# Altium schematic sheet STM32F10RBT6通用开发板_普通接口.SchDoc
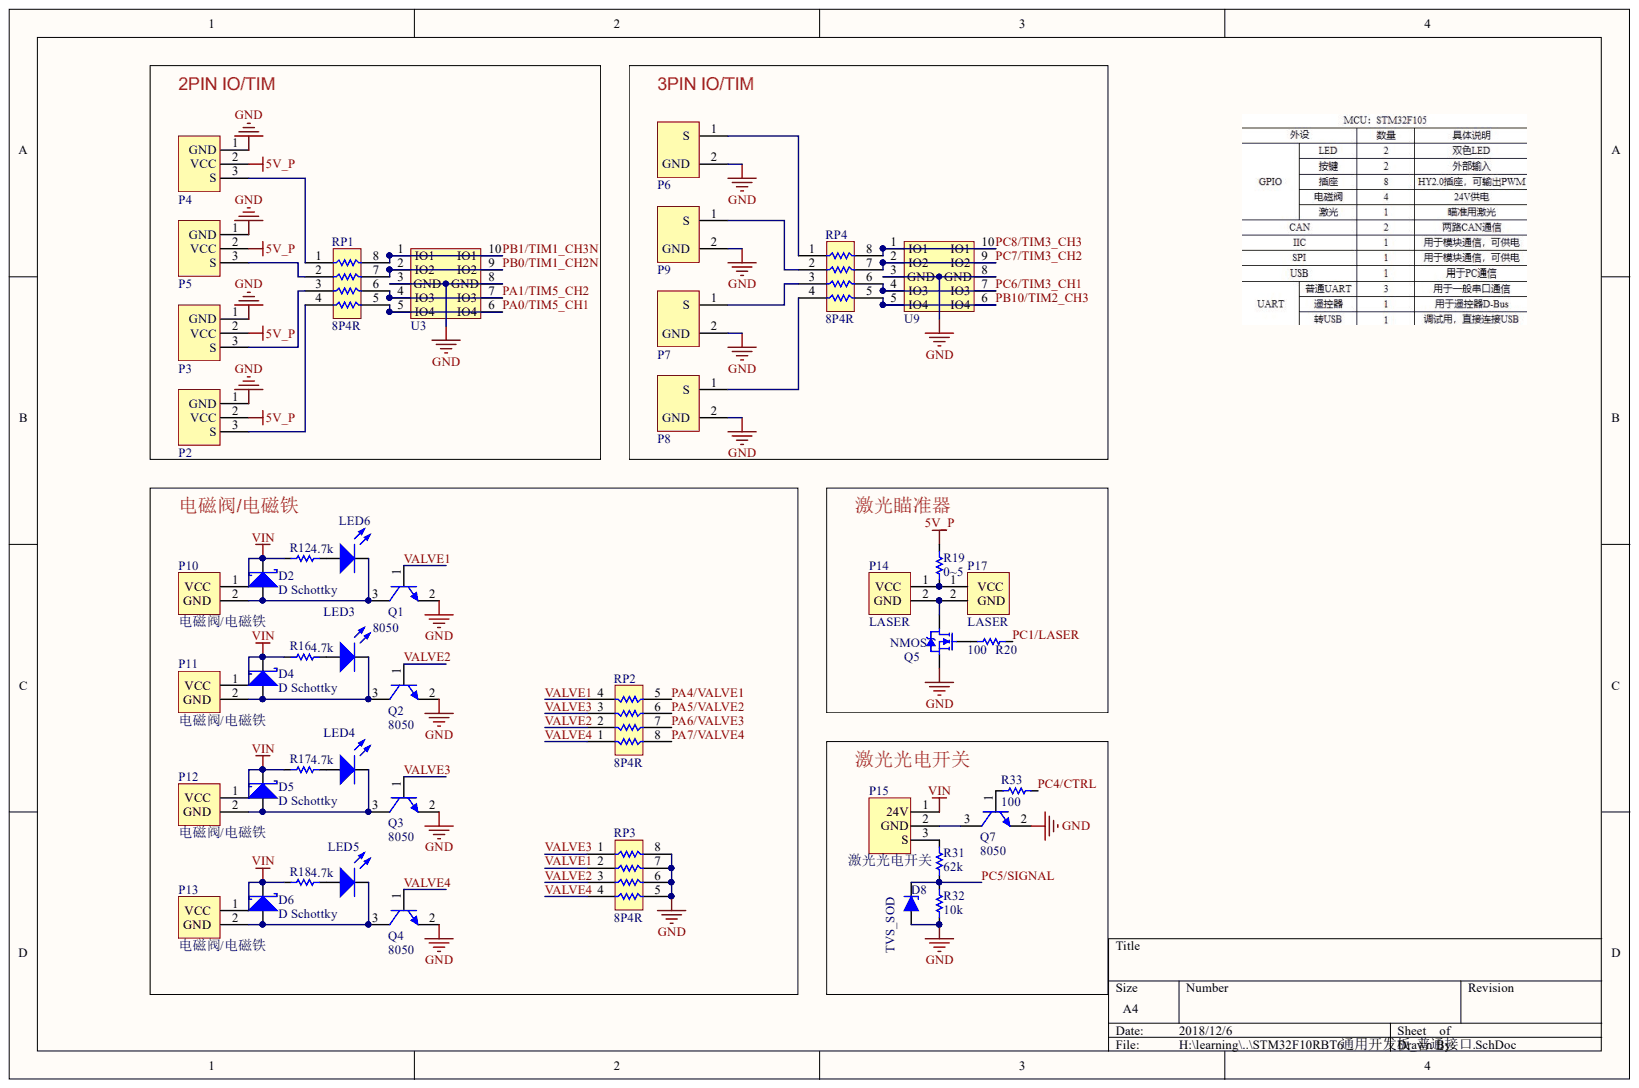
[diagram p0: STM32F10RBT6通用开发板_普通接口.SchDoc]

## Parts
D2  D Schottky  [SOD-323-]
D4  D Schottky  [SOD-323-]
D5  D Schottky  [SOD-323-]
D6  D Schottky  [SOD-323-]
D8  TVS_SOD  [SOD-323-]
LED3  LED_RED  [0603LED_B]  (value not drawn)
LED4  LED_RED  [0603LED_B]  (value not drawn)
LED5  LED_RED  [0603LED_B]  (value not drawn)
LED6  LED_RED  [0603LED_B]  (value not drawn)
P2  3Pin_IO  [HY2.00MM 3x1立式]  (value not drawn)
P3  3Pin_IO  [HY2.00MM 3x1立式]  (value not drawn)
P4  3Pin_IO  [HY2.00MM 3x1立式]  (value not drawn)
P5  3Pin_IO  [HY2.00MM 3x1立式]  (value not drawn)
P6  2pin_IO  [HY2.00MM 2X1_立式]  (value not drawn)
P7  2pin_IO  [HY2.00MM 2X1_立式]  (value not drawn)
P8  2pin_IO  [HY2.00MM 2X1_立式]  (value not drawn)
P9  2pin_IO  [HY2.00MM 2X1_立式]  (value not drawn)
P10  电磁阀/电磁铁  [HY2.00MM 2X1_立式]
P11  电磁阀/电磁铁  [HY2.00MM 2X1_立式]
P12  电磁阀/电磁铁  [HY2.00MM 2X1_立式]
P13  电磁阀/电磁铁  [HY2.00MM 2X1_立式]
P14  LASER  [HY2.00MM 2X1_立式]
P15  激光光电开关  [HY2.00MM 3x1立式]
P17  LASER  [1.25mm 2p 立式]
Q1  8050  [SOT-23-3]
Q2  8050  [SOT-23-3]
Q3  8050  [SOT-23-3]
Q4  8050  [SOT-23-3]
Q5  NMOS  [SOT-23-3]
Q7  8050  [SOT-23-3]
R12  4.7k  [0603R]  (value not drawn)
R16  4.7k  [0603R]  (value not drawn)
R17  4.7k  [0603R]  (value not drawn)
R18  4.7k  [0603R]  (value not drawn)
R19  0~5  [0603R]
R20  100  [0603R]
R31  62k  [0603R]
R32  10k  [0603R]
R33  100  [0603R]
RP1  8P4R  [RES-8P4R-0603]
RP2  8P4R  [RES-8P4R-0603]
RP3  8P4R  [RES-8P4R-0603]
RP4  8P4R  [RES-8P4R-0603]
U3  ESD-4channels_type2  [DQA0010A]  (value not drawn)
U9  ESD-4channels_type2  [DQA0010A]  (value not drawn)

## Nets
5V_P: P2.2 P3.2 P4.2 P5.2 R19.2
GND: D8.1 P2.1 P3.1 P4.1 P5.1 P6.2 P7.2 P8.2 P9.2 Q1.2 Q2.2 Q3.2 Q4.2 Q5.2 Q7.2 R32.1 RP3.5 RP3.6 RP3.7 RP3.8 U3.3(GND) U3.8(GND) U9.3(GND) U9.8(GND)
VIN: D2.2 D4.2 D5.2 D6.2 P10.1 P11.1 P12.1 P13.1 P15.1 R12.1 R16.1 R17.1 R18.1
PA0/TIM5_CH1: RP1.5 U3.5(IO4) U3.6(IO4)
PA1/TIM5_CH2: RP1.6 U3.4(IO3) U3.7(IO3)
PA4/VALVE1: RP2.5
PA5/VALVE2: RP2.6
PA6/VALVE3: RP2.7
PA7/VALVE4: RP2.8
PB0/TIM1_CH2N: RP1.7 U3.2(IO2) U3.9(IO2)
PB1/TIM1_CH3N: RP1.8 U3.1(IO1) U3.10(IO1)
PB10/TIM2_CH3: RP4.5 U9.5(IO4) U9.6(IO4)
PC1/LASER: R20.2
PC4/CTRL: R33.1
PC5/SIGNAL: D8.2 R31.1 R32.2
PC6/TIM3_CH1: RP4.6 U9.4(IO3) U9.7(IO3)
PC7/TIM3_CH2: RP4.7 U9.2(IO2) U9.9(IO2)
PC8/TIM3_CH3: RP4.8 U9.1(IO1) U9.10(IO1)
VALVE1: Q1.1 RP2.4 RP3.2
VALVE2: Q2.1 RP2.2 RP3.3
VALVE3: Q3.1 RP2.3 RP3.1
VALVE4: Q4.1 RP2.1 RP3.4
NetD2_1: D2.1 LED6.2 P10.2 Q1.3
NetD4_1: D4.1 LED3.2 P11.2 Q2.3
NetD5_1: D5.1 LED4.2 P12.2 Q3.3
NetD6_1: D6.1 LED5.2 P13.2 Q4.3
NetLED3_1: LED3.1 R16.2
NetLED4_1: LED4.1 R17.2
NetLED5_1: LED5.1 R18.2
NetLED6_1: LED6.1 R12.2
NetP2_3: P2.3 RP1.4
NetP3_3: P3.3 RP1.3
NetP4_3: P4.3 RP1.1
NetP5_3: P5.3 RP1.2
NetP6_1: P6.1 RP4.1
NetP7_1: P7.1 RP4.3
NetP8_1: P8.1 RP4.4
NetP9_1: P9.1 RP4.2
NetP14_1: P14.1 P17.1 R19.1
NetP14_2: P14.2 P17.2 Q5.3
NetP15_2: P15.2 Q7.3
NetP15_3: P15.3 R31.2
NetQ5_1: Q5.1 R20.1
NetQ7_1: Q7.1 R33.2

## Library data of the parts
D2 D4-D6 = D Schottky: Schottky Diode; Code_JEDEC: DO-214-AA; Component Kind: Standard; LatestRevisionDate: 17-Jul-2002; LatestRevisionNote: Re-released for DXP Platform.; Library Name: MyComponents_v2.5.IntLib; PackageDescription: DO-214-AA/SMB; 2 C-Bend Leads; Body 5.3 x 3.6 mm, inc. leads (LxW); PackageReference: SMB; Published: 8-Jun-2000; Signal Integrity: D Schottky; Simulation: SKYDIODE; Publisher: Altium Limited
LED3-LED6 = LED: Typical RED, GREEN, YELLOW, AMBER GaAs LED; Component Kind: Standard; LatestRevisionDate: 17-Jul-2002; LatestRevisionNote: Re-released for DXP Platform.; Library Name: MyComponents_v2.5.IntLib; PackageDescription: SM LED; 2 Flat Leads; PackageReference: 3.2X1.6X1.1; PackageVersion: Feb-2002; Published: 8-Jun-2000; Simulation: LED2; Publisher: Altium Limited
P2-P5 = 3Pin_IO: 3pin input/output. V+S+G; Component Kind: Standard; Library Name: MyComponents_v2.4.IntLib
Q1-Q4 Q7 = NPN: NPN Bipolar Transistor; Code_JEDEC: TO-226-AA; Component Kind: Standard; LatestRevisionDate: 17-Jul-2002; LatestRevisionNote: Re-released for DXP Platform.; Library Name: MyComponents_v2.5.IntLib; PackageDescription: TO, Flat Index; 3 In-Line, Axial Leads; Body Dia. 4.8mm; Leads 0.55 x 0.51 mm (max); PackageReference: TO-226-AA; Published: 8-Jun-2000; Signal Integrity: NPN; Simulation: NPN; Publisher: Altium Limited
Q5 = NMOS(SOT23): N-Channel Power MOSFET; Note: Pin 2 is electrically connected to pin 4.; Component Kind: Standard; ComponentLink1Description: Manufacturer Link; ComponentLink1URL: http://www.irf.com/; DatasheetVersion: PD-9.814; LatestRevisionDate: 17-Jul-2002; LatestRevisionNote: Re-released for DXP Platform.; Library Name: MyComponents_v2.5.IntLib; PackageDescription: TO, Thru-Hole, Vertical, Heatsink Mounted; 3 In-Line Leads; Pitch 2.54 mm; PackageReference: TO-220AB; PackageVersion: Feb-1997; Published: 8-Jun-2000; Publisher: Altium Limited
R12 R16-R20 R31-R33 = Res1: Resistor; Value: 1K; Component Kind: Standard; LatestRevisionDate: 17-Jul-2002; LatestRevisionNote: Re-released for DXP Platform.; Library Name: MyComponents_v2.5.IntLib; PackageDescription: Axial Device, Thru-Hole; 2 Leads; 0.3 in Pin Spacing; PackageReference: AXIAL-0.3; Published: 8-Jun-2000; Signal Integrity: Res; Simulation: RESISTOR; Publisher: Altium Limited
RP1-RP4 = Res-8P4R: Component Kind: Standard; Description: 8P4R; Library Name: MyComponents_v2.5.IntLib
U3 U9 = ESD-4channels_type2: Imported; Manufacturer: Texas Instruments; PartNumber: TPD4E05U06DQAR; CompType: IC; PIN_COUNT: 10; Component Kind: Standard; Library Name: MyComponents_v2.5.IntLib; MountType: SMT; PackageReference: DQA0010A; RefDes: RefDes; RoHS: Y; TYPE: TYPE; Value: Value
D8 = TVS_SOD: Component Kind: Standard; Library Name: MyComponents_v2.5.IntLib
P6-P9 = 2pin_IO: Component Kind: Standard; Library Name: MyComponents_v2.4.IntLib
P10-P13 = 电磁阀/电磁铁: Component Kind: Standard; Library Name: MyComponents_v2.5.IntLib
P14 P17 = LASER: Component Kind: Standard; Library Name: MyComponents_v2.5.IntLib
P15 = 激光光电开关: Component Kind: Standard; Library Name: MyComponents_v2.5.IntLib
